# Altium schematic sheet Antenna Interface.SchDoc
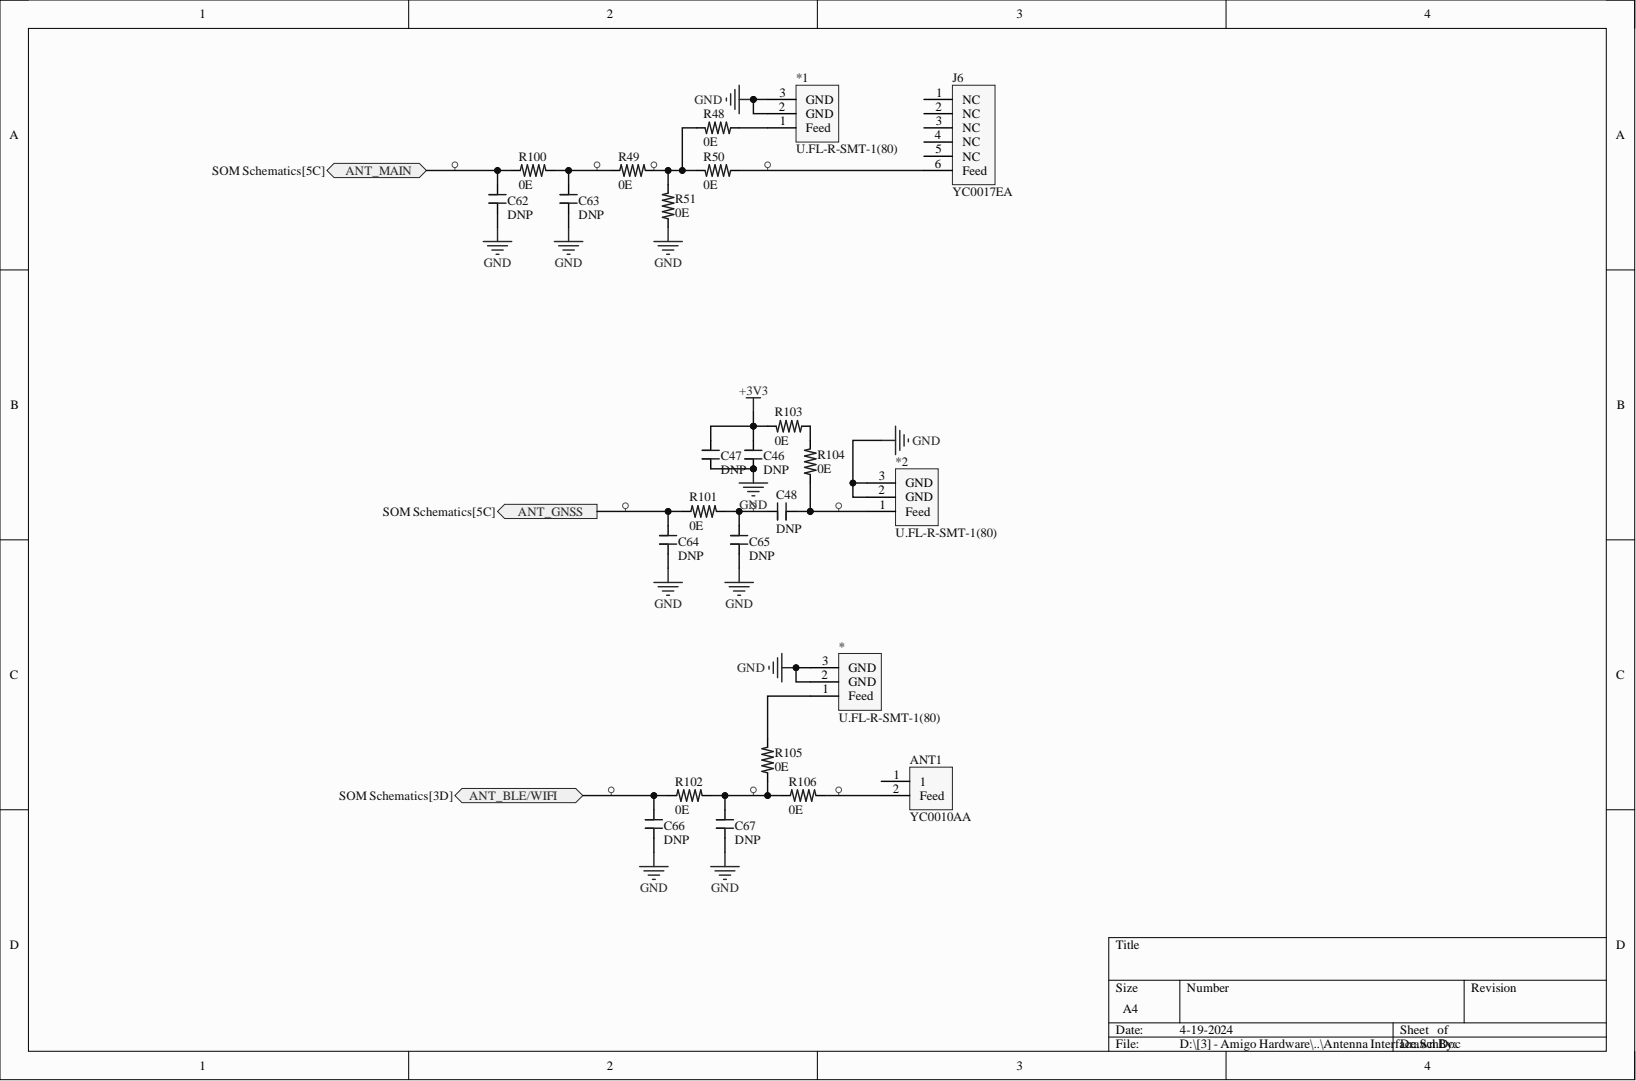
[diagram p0: Antenna Interface.SchDoc]

## Parts
*  U.FL-R-SMT-1(80)  [UFL Antenna]
*1  U.FL-R-SMT-1(80)  [UFL Antenna]
*2  U.FL-R-SMT-1(80)  [UFL Antenna]
ANT1  YC0010AA  [YC0010AA]
C46  DNP  [CAP_0603]  (no BOM)
C47  DNP  [CAP_0603]  (no BOM)
C48  DNP  [CAP_0603]  (no BOM)
C62  DNP  [CAP_0603]  (no BOM)
C63  DNP  [CAP_0603]  (no BOM)
C64  DNP  [CAP_0603]  (no BOM)
C65  DNP  [CAP_0603]  (no BOM)
C66  DNP  [CAP_0603]  (no BOM)
C67  DNP  [CAP_0603]  (no BOM)
J6  YC0017EA  [YC0017EA]
R48  0E  [RES_0603]
R49  0E  [RES_0603]
R50  0E  [RES_0603]
R51  0E  [RES_0603]
R100  0E  [RES_0603]
R101  0E  [RES_0603]
R102  0E  [RES_0603]
R103  0E  [RES_0603]
R104  0E  [RES_0603]
R105  0E  [RES_0603]
R106  0E  [RES_0603]

## Ports
ANT_BLE/WIFI, ANT_GNSS, ANT_MAIN

## Nets
+3V3: C46.2 C47.2 R103.2
GND: *.2 *.3 *1.2 *1.3 *2.2 *2.3 C46.1 C47.1 C62.1 C63.1 C64.1 C65.1 C66.1 C67.1 R51.1
ANT_BLE/WIFI: C66.2 R102.1 ; port ANT_BLE/WIFI
ANT_GNSS: C64.2 R101.1 ; port ANT_GNSS
ANT_MAIN: C62.2 R100.1 ; port ANT_MAIN
Net*1_1: *1.1 R48.1
Net*2_1: *2.1 C48.1 R104.1
Net*_1: *.1 R105.2
NetANT1_2: ANT1.2 R106.2
NetC48_2: C48.2 C65.2 R101.2
NetC63_2: C63.2 R49.1 R100.2
NetC67_2: C67.2 R102.2 R105.1 R106.1
NetJ6_6: J6.6(Feed) R50.1
NetR48_2: R48.2 R49.2 R50.2 R51.2
NetR103_1: R103.1 R104.2

Not connected: ANT1 1; J6 1(NC) 2(NC) 3(NC) 4(NC) 5(NC)

## Library data of the parts
* *1 *2 = U.FL-R-SMT-1(80): RF Connector, 1 Contact(s), Male, Board Mount, Surface Mount Terminal, Receptacle; Manufacturer: Hirose; Body Breadth: 0.122inch; Body Depth: 0.049inch; Body Length: 0.118inch; Dielectric Withstanding Voltage (AC): 200V; Durability: 30Cycles; Insulation Resistance: 500MR; Mounting Option 1: LOCKING; Number of Contacts: 1; Operating Frequency-Max: 6kHz; Reference Standard: UL; Reliability: COMMERCIAL; Body/Shell Style: RECEPTACLE; Category: RF / Coaxial Connectors; Component Kind: Standard; ComponentLink1Description: https://datasheet.ciiva.com:443/pdfs/VipMasterIC/IC/HIRO/HIRO-S-A0007913874/HIRO-S-A0009147602-1.pdf?src-supplier=IHS+Markit; ComponentLink1URL: https://datasheet.ciiva.com:443/pdfs/VipMasterIC/IC/HIRO/HIRO-S-A0007913874/HIRO-S-A0009147602-1.pdf?src-supplier=IHS+Markit; ComponentLink2Description: https://www.hirose.com/product/en/download_file/key_name/U.FL-R-SMT-1%2880%29/category/Specification%20Sheet/doc_file_id/37638/?file_category_id=9&item_id=03310472280&is_series=&src-supplier=Digikey; ComponentLink2URL: https://www.hirose.com/product/en/download_file/key_name/U.FL-R-SMT-1%2880%29/category/Specification%20Sheet/doc_file_id/37638/?file_category_id=9&item_id=03310472280&is_series=&src-supplier=Digikey; ComponentLink3Description: https://datasheet.octopart.com/U.FL-R-SMT-1%2880%29-Hirose-datasheet-157246094.pdf?src-supplier=LKR; ComponentLink3URL: https://datasheet.octopart.com/U.FL-R-SMT-1%2880%29-Hirose-datasheet-157246094.pdf?src-supplier=LKR; Connector Type: RF CONNECTOR; Contact Gender: Male; Insulation Material: Polymer; Library Name: EM_LIBRARY_1.IntLib; Manufacturer Part Number: U.FL-R-SMT-1(80); Max Operating Temperature: 90°C; Min Operating Temperature: -40°C; Mounting Style: STRAIGHT; Mounting Technology: Board; Number of Ports: 1; Pricing: 1=1.32, 10=1.114, 25=1.068, 50=1.0454, 100=0.9999, 250=0.909, 500=0.8181, 1000=0.7272, 2500=0.65902 (USD); Reach SVHC Compliant: Yes; RoHS: Yes; RoHS Compliant: Yes; Shell Finish: Silver; Shell Material: CuSn; Stock: 118067; Supplier: Digikey; Supplier Part Number: 26-U.FL-R-SMT-1(80)CT-ND; Termination: SMD/SMT
ANT1 = YC0010AA: Manufacturer: Quectel Wireless Solutions; Category: RF Antennas; Component Kind: Standard; Library Name: EM_LIBRARY_1.IntLib; Manufacturer Part Number: YC0010AA; Pricing: 1=0.55, 10=0.469, 25=0.4376, 100=0.35, 250=0.325, 500=0.275, 1000=0.2125 (USD); RoHS: No; Stock: 8553; Supplier: Digikey; Supplier Part Number: 2958-YC0010AACT-ND
C46-C48 C62-C67 = CAP_NOT-MOUNTED_0603: Do Not Place this Capacitor 0603; Manufacturer: KEMET; Manufacturer Part Number: C0603C103K8RACTU; Capacitance: 10nF; Dielectric: X7R; Dielectric Material: Ceramic; Dissipation Factor: 5%; Tolerance: 10%; Voltage Rating: 10V; Case Code (Imperial): 0603; Case Code (Metric): 1608; Case/Package: 0603; Category: Ceramic Capacitors; ComponentLink1Description: https://datasheet.ciiva.com/49516/1564916-49516523.pdf?src-supplier=Jameco; ComponentLink1URL: https://datasheet.ciiva.com/49516/1564916-49516523.pdf?src-supplier=Jameco; Construction: Flat; Depth: 0.8mm; Height: 0.8mm; Lead Pitch: 0.7mm; Length: 1.6mm; Library Name: EM_LIBRARY_1.IntLib; Manufacturer 1: KEMET; Manufacturer Part Number 1: C0603C103K8RACTU; Max Operating Temperature: 125°C; Min Operating Temperature: -55°C; Mount: Surface Mount; Package Quantity: 1; Packaging: Tape and Reel; Pricing 1: 1=0.1, 10=0.034, 50=0.034, 100=0.02, 1000=0.016, 10000=0.011 (USD); Reach SVHC Compliant: No; RoHS: Yes; Series: -; Stock 1: 6134; Supplier 1: Mouser; Supplier Part Number 1: 80-C0603C103K8R; Supplier-1: Digikey; Termination: SMD/SMT; Thickness: 0.034inch; Voltage Rating (DC): 10V; Width: 0.031inch
J6 = YC0017EA: Manufacturer: Quectel Wireless Solutions; Category: Uncategorized; Component Kind: Standard; Library Name: EM_LIBRARY_1.IntLib; Manufacturer Part Number: YC0017EA; Pricing: 1=1.24, 10=1.106, 25=1.05, 100=0.8625, 250=0.80624, 500=0.7125 (USD); RoHS: No; Stock: 2550; Supplier: Digikey; Supplier Part Number: 2958-YC0017EACT-ND
R48-R51 R100-R106 = RES_0E_0.1W_0603: Metal Glaze/thick Film, 0.1W, 0ohm, Surface Mount, 0603; Manufacturer: Yageo; Manufacturer Part Number: RC0603JR-070RL; Package Height: 0.45mm; Package Length: 1.6mm; Package Width: 0.8mm; Power: 100mW; Rated Temperature: 70°C; Value: 0R; Case/Package: 0603; Category: Chip SMD Resistors; Component Kind: Standard; ComponentLink1Description: https://datasheet.ciiva.com:443/pdfs/VipMasterIC/IC/YAGO/YAGO-S-A0008145938/YAGO-S-A0008145938-1.pdf?src-supplier=IHS+Markit; ComponentLink1URL: https://datasheet.ciiva.com:443/pdfs/VipMasterIC/IC/YAGO/YAGO-S-A0008145938/YAGO-S-A0008145938-1.pdf?src-supplier=IHS+Markit; Construction: Chip; JESD-609 Code: e3; Library Name: EM_LIBRARY_1.IntLib; Max Operating Temperature: 155°C; Min Operating Temperature: -55°C; Mounting Technology: Surface Mount; Package Shape: Rectangular; Package Style: SMTMeter; Packaging: Tape and Reel; Pins: 2; Pricing: 1=0.1, 10=0.015, 25=0.0112, 50=0.0086, 100=0.006, 250=0.0048, 500=0.00386, 1000=0.00284 (USD); Reach SVHC Compliant: Yes; Resistor Type: FIXED RESISTOR; RoHS: Yes; RoHS Compliant: Yes; Stock: 1005063; Supplier: Digi-Key; Supplier Part Number: 311-0.0GRCT-ND; Technology: METAL GLAZE/THICK FILM; Terminal Finish: Nickel; Termination: Wraparound
